# Altium schematic sheet 02_RNWF UART to Cloud Add on Board .SchDoc("02_RNWF UART to Cloud Add on Board ")
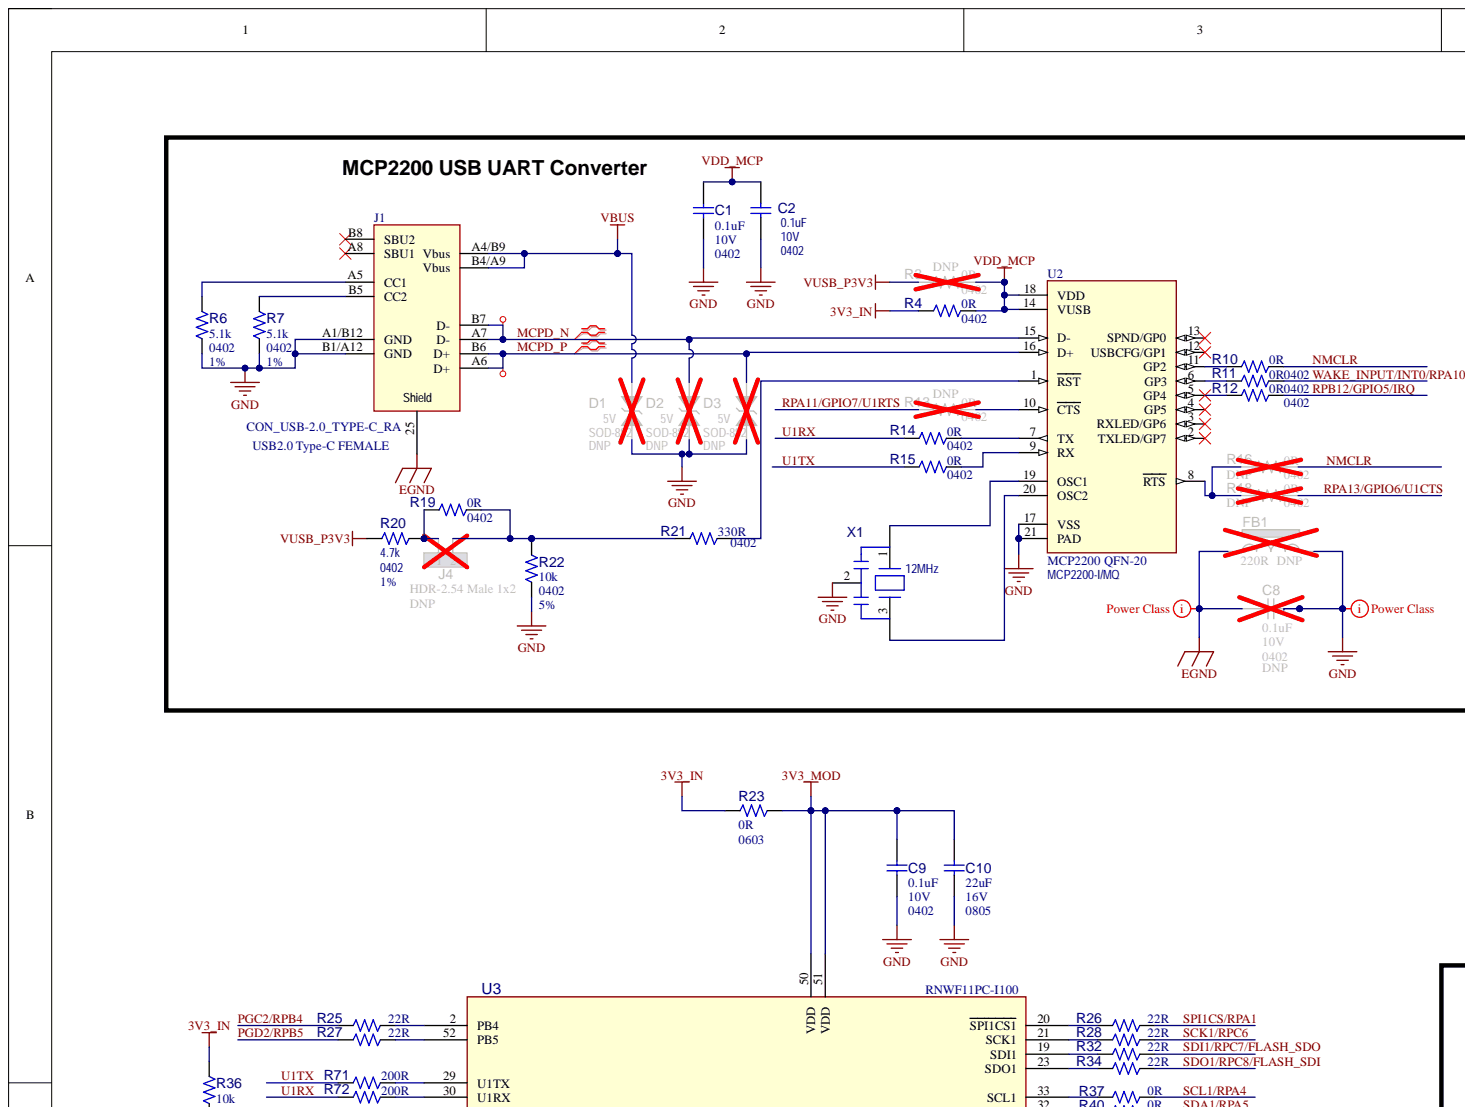
[diagram p0: 02_RNWF UART to Cloud Add on Board .SchDoc, top left]
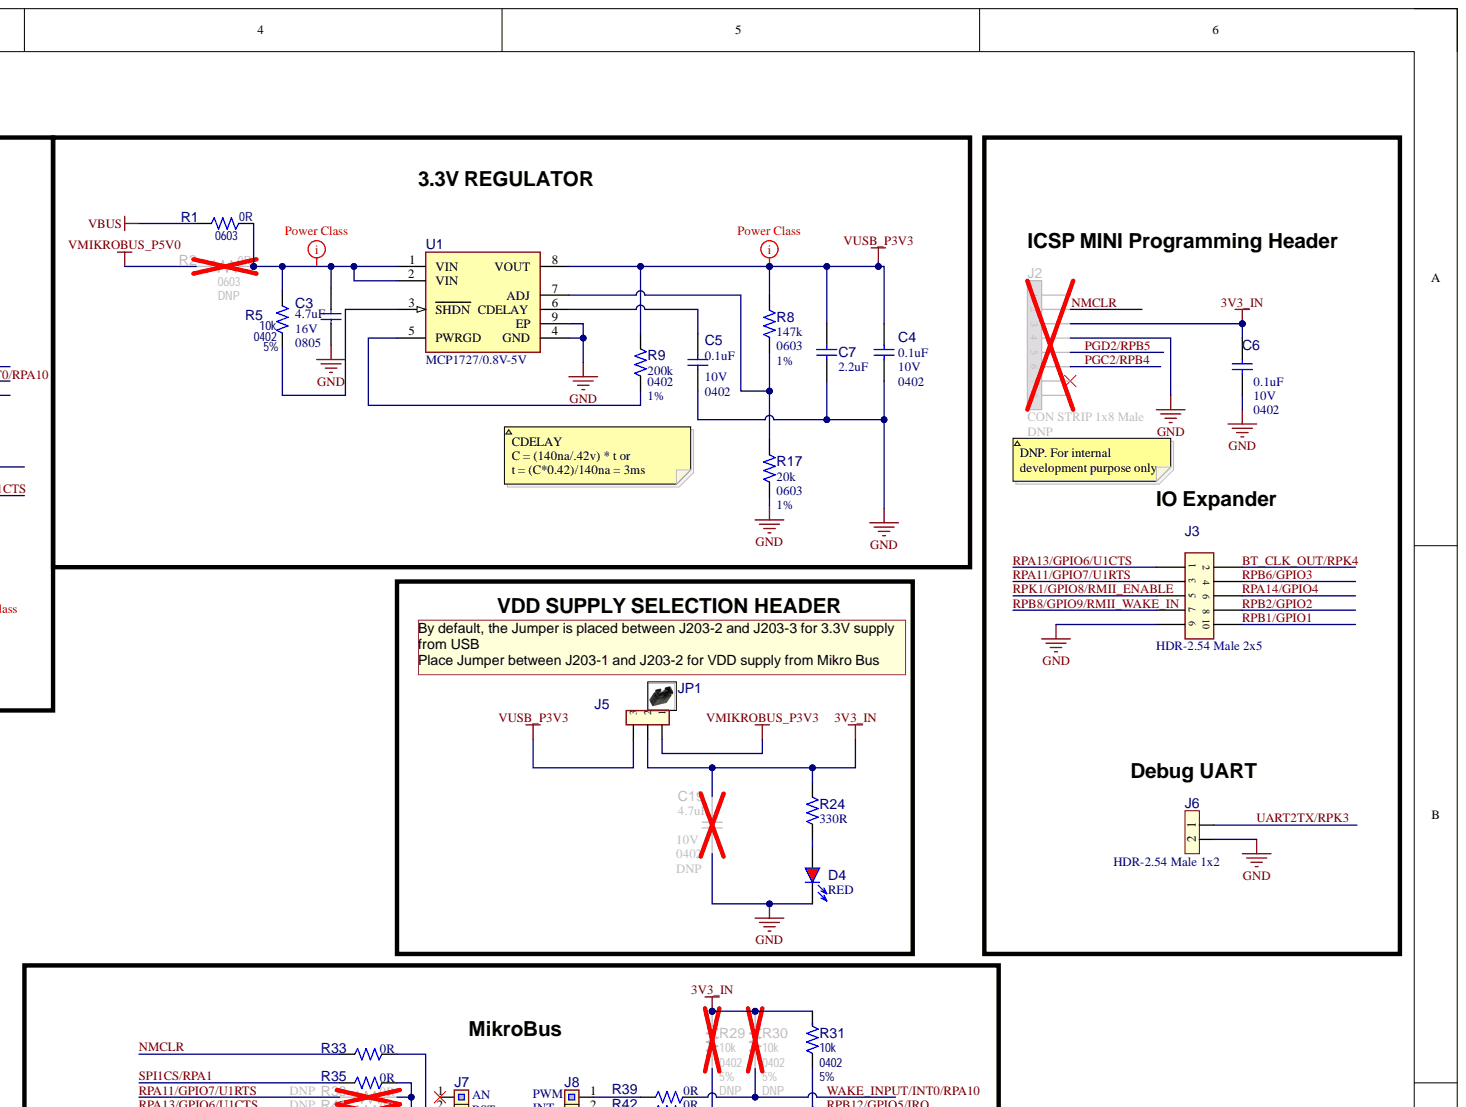
[diagram p1: 02_RNWF UART to Cloud Add on Board .SchDoc, top right]
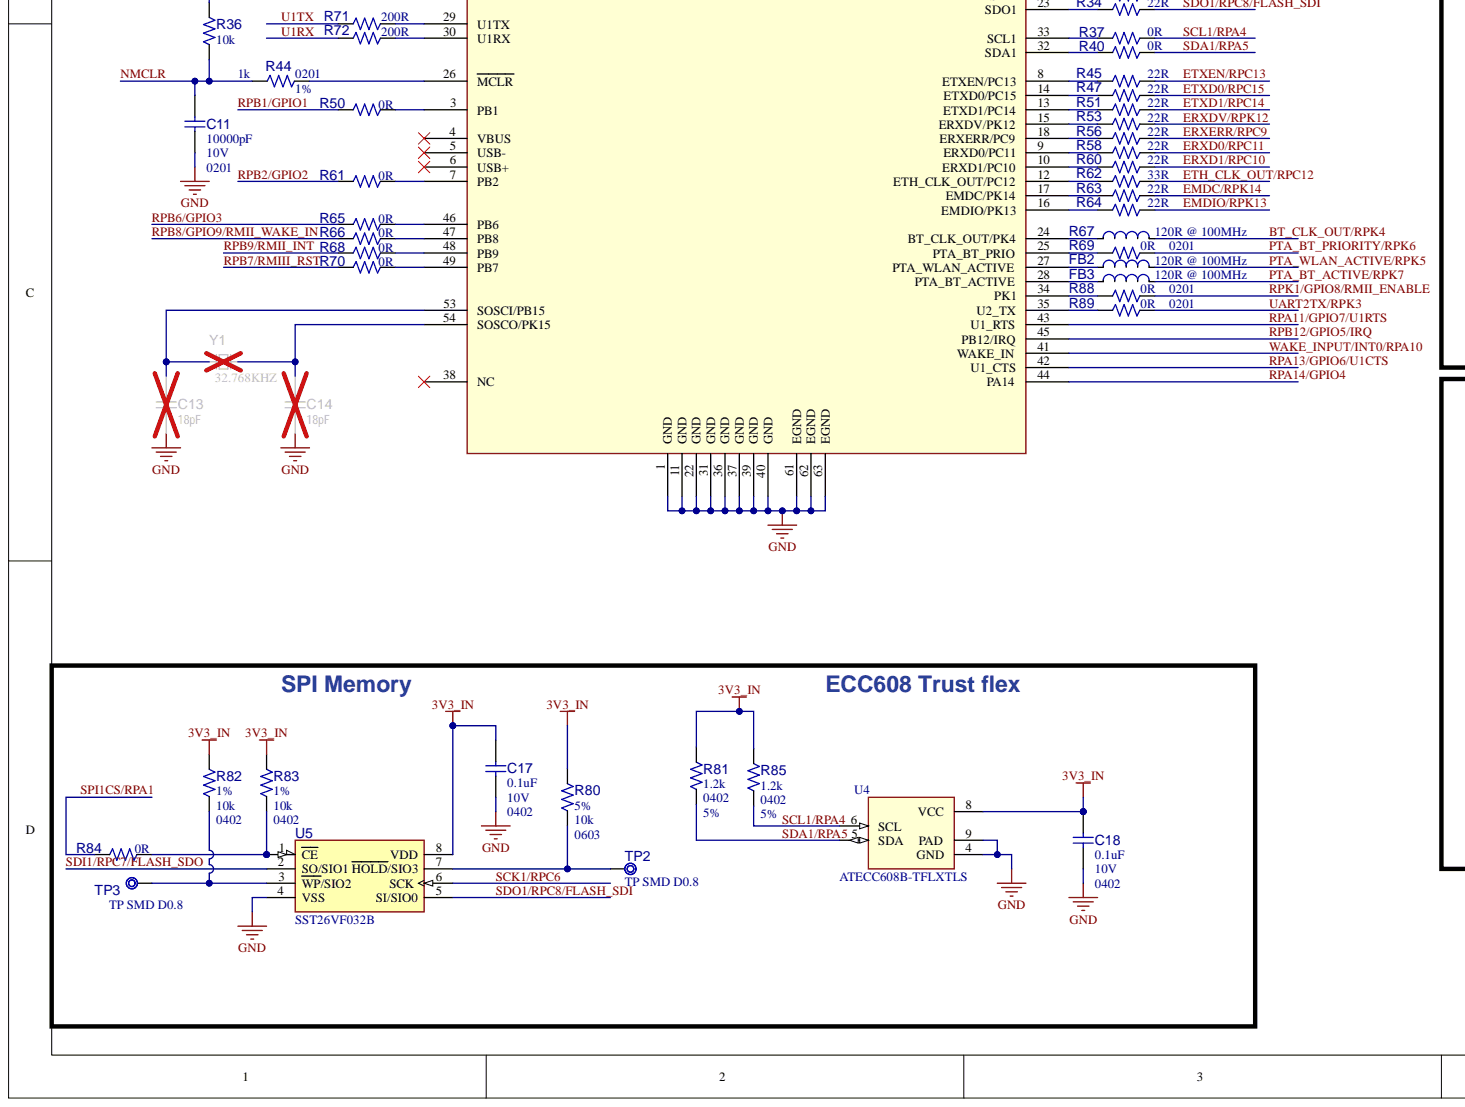
[diagram p2: 02_RNWF UART to Cloud Add on Board .SchDoc, bottom left]
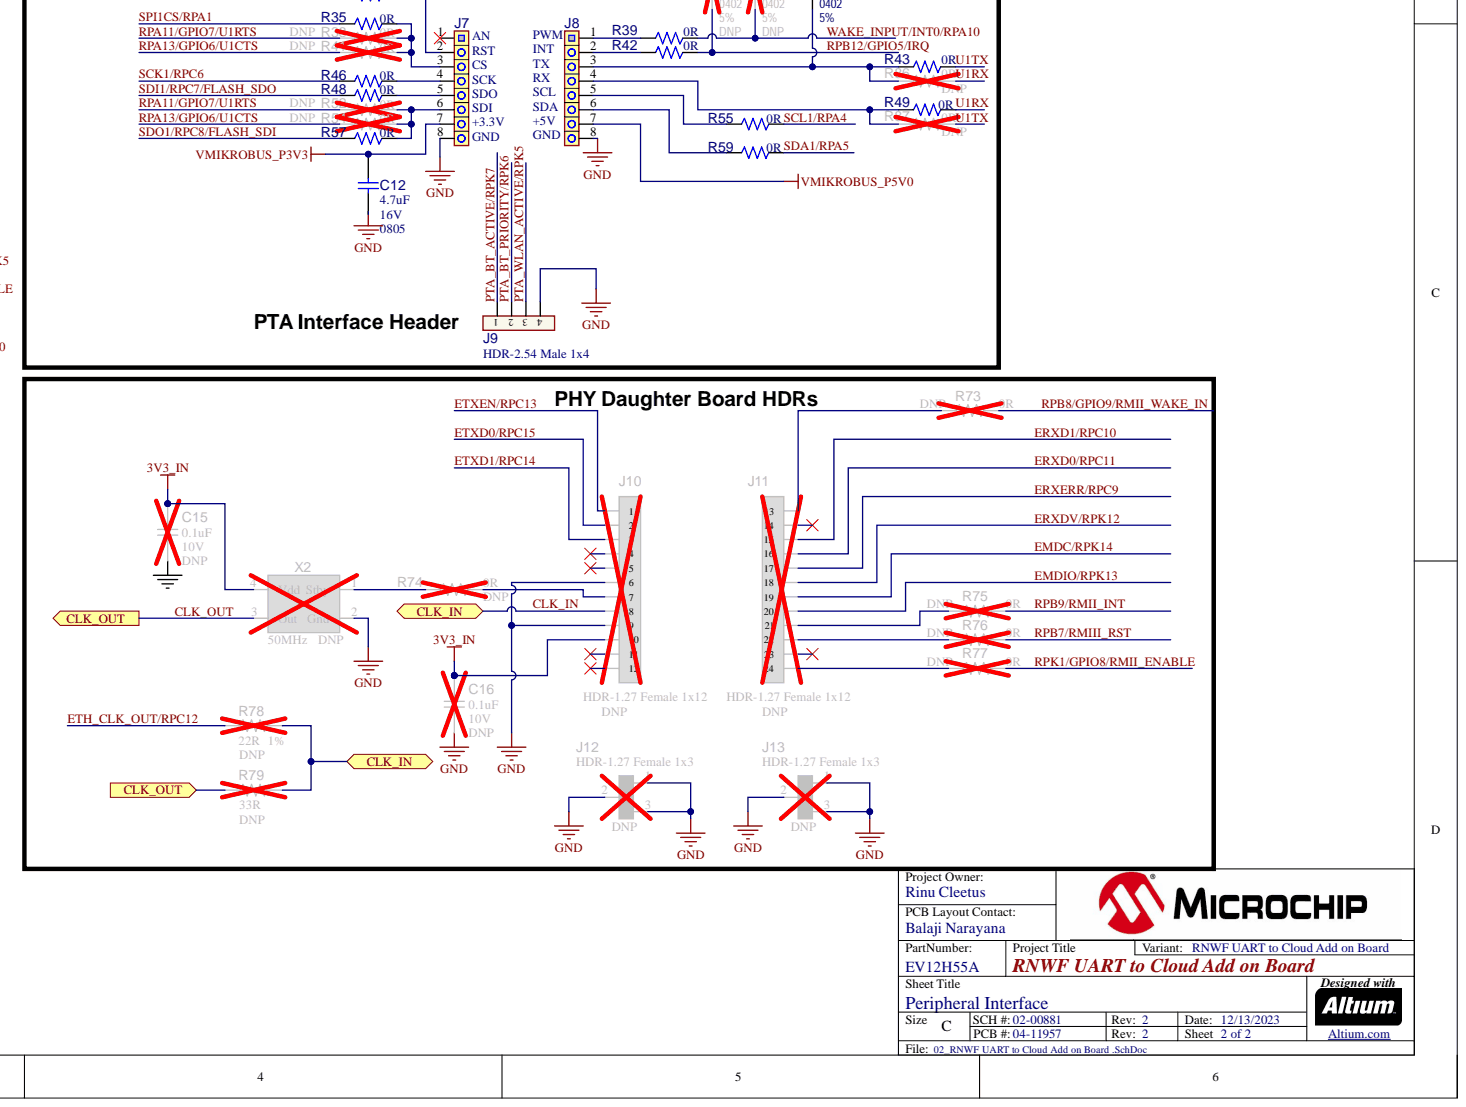
[diagram p3: 02_RNWF UART to Cloud Add on Board .SchDoc, bottom right]

## Parts
C1  value 0.1uF  Package: 0402  Voltage: 10V  [CAP_C_0402]  (hidden comment: Capacitor NP)
C2  value 0.1uF  Package: 0402  Voltage: 10V  [CAP_C_0402]  (hidden comment: Capacitor NP)
C3  value 4.7uF  Package: 0805  Voltage: 16V  [CAP_C_0805]  (hidden comment: Capacitor NP)
C4  value 0.1uF  Package: 0402  Voltage: 10V  [CAP_C_0402]  (hidden comment: Capacitor NP)
C5  value 0.1uF  Package: 0402  Voltage: 10V  [CAP_C_0402]  (hidden comment: Capacitor NP)
C6  value 0.1uF  Package: 0402  Voltage: 10V  [CAP_C_0402]  (hidden comment: Capacitor NP)
C7  value 2.2uF  [CAP_C_0603]  (hidden comment: Capacitor NP)
C8  value 0.1uF  Package: 0402  Populated: DNP  Voltage: 10V  [CAP_C_0402]  (hidden comment: Capacitor NP)
C9  value 0.1uF  Package: 0402  Voltage: 10V  [CAP_C_0402]  (hidden comment: Capacitor NP)
C10  value 22uF  Package: 0805  Voltage: 16V  [CAP_C_0805]  (hidden comment: Capacitor NP)
C11  value 10000pF  Package: 0201  Voltage: 10V  [CAP_C_0201]  (hidden comment: Capacitor NP)
C12  value 4.7uF  Package: 0805  Voltage: 16V  [CAP_C_0805]  (hidden comment: Capacitor NP)
C13  value 18pF  [CAP_C_0402]  (hidden comment: Capacitor NP)
C14  value 18pF  [CAP_C_0402]  (hidden comment: Capacitor NP)
C15  value 0.1uF  Populated: DNP  Voltage: 10V  [CAP_C_0402]  (hidden comment: Capacitor NP)
C16  value 0.1uF  Populated: DNP  Voltage: 10V  [CAP_C_0402]  (hidden comment: Capacitor NP)
C17  value 0.1uF  Package: 0402  Voltage: 10V  [CAP_C_0402]  (hidden comment: Capacitor NP)
C18  value 0.1uF  Package: 0402  Voltage: 10V  [CAP_C_0402]  (hidden comment: Capacitor NP)
C19  value 4.7uF  Package: 0402  Populated: DNP  Voltage: 10V  [CAP_C_0402]  (hidden comment: Capacitor NP)
D1  value 5V  Populated: DNP  Reverse Voltage: 5V  [SOD-882]  (hidden comment: Diode TVS Bidirectional)
D2  value 5V  Populated: DNP  Reverse Voltage: 5V  [SOD-882]  (hidden comment: Diode TVS Bidirectional)
D3  value 5V  Populated: DNP  Reverse Voltage: 5V  [SOD-882]  (hidden comment: Diode TVS Bidirectional)
D4  value RED  [LED_0603_RED]  (hidden comment: LED_red)
FB1  value 220R  Populated: DNP  [IND_C_0805]  (hidden comment: Ferrite Bead)
FB2  value 120R @ 100MHz  [RES_C_0402]  (hidden comment: Inductor)
FB3  value 120R @ 100MHz  [RES_C_0402]  (hidden comment: Inductor)
J1  CON_USB-2.0_TYPE-C_RA  value USB2.0 Type-C FEMALE  [CON_USB-2.0_TYPE-C_USB4105_SMD]
J2  value CON STRIP 1x8 Male  Populated: DNP  [HDR_M_1.27_1x8]  (hidden comment: Header 1x8)
J3  value HDR-2.54 Male 2x5  [HDR_M_2.54_2x5]  (hidden comment: Header 2x5)
J4  value HDR-2.54 Male 1x2  Populated: DNP  [HDR_M_2.54_1x2]  (hidden comment: Header 1x2)
J5  Header 1x3  [HDR_M_2.54_1x3]  (value not drawn)
J6  value HDR-2.54 Male 1x2  [HDR_M_2.54_1x2]  (hidden comment: Header 1x2)
J7  Header 1x8  [HDR_M_2.54_1x8]  (value not drawn)
J8  Header 1x8  [HDR_M_2.54_1x8]  (value not drawn)
J9  Header 1x4  value HDR-2.54 Male 1x4  [HDR_M_2.54_1x4]
J10  value HDR-1.27 Female 1x12  Populated: DNP  [HDR_F_1.27_1x12_U_TH_V_SAM_SLM-112-01-L-S]  (hidden comment: Header 1x12)
J11  value HDR-1.27 Female 1x12  Populated: DNP  [HDR_F_1.27_1x12_U_TH_V_SAM_SLM-112-01-L-S_1]  (hidden comment: Header 1x12)
J12  value HDR-1.27 Female 1x3  Populated: DNP  [HDR_F_1.27_1x3_U_TH_V_SAM_SLM-103-01-L-S]  (hidden comment: Header 1x3)
J13  value HDR-1.27 Female 1x3  Populated: DNP  [HDR_F_1.27_1x3_U_TH_V_SAM_SLM-103-01-L-S]  (hidden comment: Header 1x3)
JP1  Jumper Mechanical  [Jumper_W_Handle_Mechanical]  (value not drawn)  (mechanical)
R1  value 0R  Package: 0603  [RES_C_0603]  (hidden comment: Resistor)
R2  value 0R  Package: 0603  [RES_C_0603]  (hidden comment: Resistor)
R3  value 0R  Package: 0402  Populated: DNP  [RES_C_0402]  (hidden comment: Resistor)
R4  value 0R  Package: 0402  [RES_C_0402]  (hidden comment: Resistor)
R5  value 10k  Package: 0402  Tolerance: 5%  [RES_C_0402]  (hidden comment: Resistor)
R6  value 5.1k  Package: 0402  Tolerance: 1%  [RES_C_0402]  (hidden comment: Resistor)
R7  value 5.1k  Package: 0402  Tolerance: 1%  [RES_C_0402]  (hidden comment: Resistor)
R8  value 147k  Package: 0603  Tolerance: 1%  [RES_C_0603]  (hidden comment: Resistor)
R9  value 200k  Package: 0402  Tolerance: 1%  [RES_C_0402]  (hidden comment: Resistor)
R10  value 0R  Package: 0402  [RES_C_0402]  (hidden comment: Resistor)
R11  value 0R  Package: 0402  [RES_C_0402]  (hidden comment: Resistor)
R12  value 0R  Package: 0402  [RES_C_0402]  (hidden comment: Resistor)
R13  value 0R  Package: 0402  Populated: DNP  [RES_C_0402]  (hidden comment: Resistor)
R14  value 0R  Package: 0402  [RES_C_0402]  (hidden comment: Resistor)
R15  value 0R  Package: 0402  [RES_C_0402]  (hidden comment: Resistor)
R16  value 0R  Package: 0402  Populated: DNP  [RES_C_0402]  (hidden comment: Resistor)
R17  value 20k  Package: 0603  Tolerance: 1%  [RES_C_0603]  (hidden comment: Resistor)
R18  value 0R  Package: 0402  Populated: DNP  [RES_C_0402]  (hidden comment: Resistor)
R19  value 0R  Package: 0402  [RES_C_0402]  (hidden comment: Resistor)
R20  value 4.7k  Package: 0402  Tolerance: 1%  [RES_C_0402]  (hidden comment: Resistor)
R21  value 330R  Package: 0402  [RES_C_0402]  (hidden comment: Resistor)
R22  value 10k  Package: 0402  Tolerance: 5%  [RES_C_0402]  (hidden comment: Resistor)
R23  value 0R  Package: 0603  [RES_C_0603]  (hidden comment: Resistor)
R24  value 330R  [RES_C_0402]  (hidden comment: Resistor)
R25  value 22R  [RES_C_0402]  (hidden comment: Resistor)
R26  value 22R  [RES_C_0402]  (hidden comment: Resistor)
R27  value 22R  [RES_C_0402]  (hidden comment: Resistor)
R28  value 22R  [RES_C_0402]  (hidden comment: Resistor)
R29  value 10k  Package: 0402  Populated: DNP  Tolerance: 5%  [RES_C_0402]  (hidden comment: Resistor)
R30  value 10k  Package: 0402  Populated: DNP  Tolerance: 5%  [RES_C_0402]  (hidden comment: Resistor)
R31  value 10k  Package: 0402  Tolerance: 5%  [RES_C_0402]  (hidden comment: Resistor)
R32  value 22R  [RES_C_0402]  (hidden comment: Resistor)
R33  value 0R  [RES_C_0402]  (hidden comment: Resistor)
R34  value 22R  [RES_C_0402]  (hidden comment: Resistor)
R35  value 0R  [RES_C_0402]  (hidden comment: Resistor)
R36  value 10k  [RES_C_0402]  (hidden comment: Resistor)
R37  value 0R  [RES_C_0402]  (hidden comment: Resistor)
R38  value 0R  Populated: DNP  [RES_C_0402]  (hidden comment: Resistor)
R39  value 0R  [RES_C_0402]  (hidden comment: Resistor)
R40  value 0R  [RES_C_0402]  (hidden comment: Resistor)
R41  value 0R  Populated: DNP  [RES_C_0402]  (hidden comment: Resistor)
R42  value 0R  [RES_C_0402]  (hidden comment: Resistor)
R43  value 0R  [RES_C_0402]  (hidden comment: Resistor)
R44  value 1k  Package: 0201  Tolerance: 1%  [RES_C_0201]  (hidden comment: Resistor)
R45  value 22R  [RES_C_0402]  (hidden comment: Resistor)
R46  value 0R  [RES_C_0402]  (hidden comment: Resistor)
R47  value 22R  [RES_C_0402]  (hidden comment: Resistor)
R48  value 0R  [RES_C_0402]  (hidden comment: Resistor)
R49  value 0R  [RES_C_0402]  (hidden comment: Resistor)
R50  value 0R  [RES_C_0402]  (hidden comment: Resistor)
R51  value 22R  [RES_C_0402]  (hidden comment: Resistor)
R52  value 0R  Populated: DNP  [RES_C_0402]  (hidden comment: Resistor)
R53  value 22R  [RES_C_0402]  (hidden comment: Resistor)
R54  value 0R  Populated: DNP  [RES_C_0402]  (hidden comment: Resistor)
R55  value 0R  [RES_C_0402]  (hidden comment: Resistor)
R56  value 22R  [RES_C_0402]  (hidden comment: Resistor)
R57  value 0R  [RES_C_0402]  (hidden comment: Resistor)
R58  value 22R  [RES_C_0402]  (hidden comment: Resistor)
R59  value 0R  [RES_C_0402]  (hidden comment: Resistor)
R60  value 22R  [RES_C_0402]  (hidden comment: Resistor)
R61  value 0R  [RES_C_0402]  (hidden comment: Resistor)
R62  value 33R  [RES_C_0402]  (hidden comment: Resistor)
R63  value 22R  [RES_C_0402]  (hidden comment: Resistor)
R64  value 22R  [RES_C_0402]  (hidden comment: Resistor)
R65  value 0R  [RES_C_0402]  (hidden comment: Resistor)
R66  value 0R  [RES_C_0402]  (hidden comment: Resistor)
R67  value 120R @ 100MHz  [RES_C_0402]  (hidden comment: Inductor)
R68  value 0R  [RES_C_0402]  (hidden comment: Resistor)
R69  value 0R  Package: 0201  [RES_C_0201]  (hidden comment: Resistor)
R70  value 0R  [RES_C_0402]  (hidden comment: Resistor)
R71  value 200R  [RES_C_0402]  (hidden comment: Resistor)
R72  value 200R  [RES_C_0402]  (hidden comment: Resistor)
R73  value 0R  Populated: DNP  [RES_C_0402]
R74  value 0R  Populated: DNP  [RES_C_0402]
R75  value 0R  Populated: DNP  [RES_C_0402]
R76  value 0R  Populated: DNP  [RES_C_0402]
R77  value 0R  Populated: DNP  [RES_C_0402]
R78  value 22R  Tolerance: 1%  [RES_C_0402]  (hidden comment: Resistor)
R79  value 33R  Populated: DNP  [RES_C_0402]  (hidden comment: Resistor)
R80  value 10k  Package: 0603  Tolerance: 5%  [RES_C_0603]  (hidden comment: RES0191)
R81  value 1.2k  Package: 0402  Tolerance: 5%  [RES_C_0402]  (hidden comment: Resistor)
R82  value 10k  Package: 0402  Tolerance: 1%  [RES_C_0402]  (hidden comment: RSMT1019)
R83  value 10k  Package: 0402  Tolerance: 1%  [RES_C_0402]  (hidden comment: RSMT1019)
R84  value 0R  [RES_C_0402]  (hidden comment: Resistor)
R85  value 1.2k  Package: 0402  Tolerance: 5%  [RES_C_0402]  (hidden comment: Resistor)
R86  value 0R  Populated: DNP  [RES_C_0402]  (hidden comment: Resistor)
R87  value 0R  Populated: DNP  [RES_C_0402]  (hidden comment: Resistor)
R88  value 0R  Package: 0201  [RES_C_0201]  (hidden comment: Resistor)
R89  value 0R  Package: 0201  [RES_C_0201]  (hidden comment: Resistor)
TP2  value TP SMD D0.8  [CON_TP_0.8dia_SMD]  (hidden comment: Test Point No BOM)  (no BOM)
TP3  value TP SMD D0.8  [CON_TP_0.8dia_SMD]  (hidden comment: Test Point No BOM)  (no BOM)
U1  value MCP1727/0.8V-5V  [DFN-8_3x3]  (hidden comment: MCP172X ADJ DFN-8)
U2  MCP2200 QFN-20  value MCP2200-I/MQ  Manufacturer Part Number: MCP2200-I/MQ  [QFN-20_5X5]
U3  value RNWF11PC-I100  Manufacturer Part Number 1: RNWF11PC-I100  [MOD_WFI32E01x]  (hidden comment: MODULE RNWF11PC-I)
U4  value ATECC608B-TFLXTLS  [UDFN-8_2X3_EP1.5X1.3]  (hidden comment: ATECC608B-MAHDA-B UDFN-8)
U5  value SST26VF032B  [SOIJ-8]  (hidden comment: SST26VFXXX SOIC-8_WSON-8_SOIJ-8)
X1  value 12MHz  [RESONATOR_CSTCE-G_L3.2W1.3H0.8]  (hidden comment: Resonator 3 Pins)
X2  value 50MHz  Populated: DNP  [CRYSTAL_ASEMB]  (hidden comment: XTAL_OSC-ASEMB)
Y1  value 32.768KHZ  [CRYSTAL_FC-12M_L2.05W1.2H0.6]  (hidden comment: Crystal 2 Pins)

## Ports
CLK_IN, CLK_OUT

## Nets
3V3_IN: C6.1 C15.2 C16.2 C17.1 C18.1 C19.2 J2.3 J5.2 J10.10 R4.1 R23.1 R24.1 R29.2 R30.2 R31.2 R36.2 R80.2 R81.2 R82.2 R83.2 R85.2 U4.8(VCC) U5.8(VDD) X2.4(Vdd)
3V3_MOD: C9.2 C10.2 R23.2 U3.50(VDD) U3.51(VDD)
EGND: C8.2 FB1.1 J1.25
GND: C1.2 C2.1 C3.1 C4.1 C5.1 C6.2 C7.1 C8.1 C9.1 C10.1 C11.1 C12.1 C13.1 C14.1 C15.1 C16.1 C17.2 C18.2 C19.1 D1.1 D2.1 D3.1 D4.2 FB1.2 J1.A1/B12(GND) J1.B1/A12(GND) J2.4 J3.9 J6.2 J7.8 J8.8 J9.4 J10.6 J10.9 J12.1 J12.2 J12.3 J13.1 J13.2 J13.3 R6.1 R7.1 R17.1 R22.1 U1.4(GND) U1.9(EP) U2.17(VSS) U2.21(PAD) U3.1(GND) U3.11(GND) U3.22(GND) U3.31(GND) U3.36(GND) U3.37(GND) U3.39(GND) U3.40(GND) U3.61(EGND) U3.62(EGND) U3.63(EGND) U4.4(GND) U4.9(PAD) U5.4(VSS) X1.2 X2.2(Gnd)
VBUS: D1.2 J1.A4/B9(Vbus) J1.B4/A9(Vbus) R1.1
VDD_MCP: C1.1 C2.2 R3.2 R4.2 U2.14(VUSB) U2.18(VDD)
VMIKROBUS_P3V3: C12.2 J5.1 J7.7
VMIKROBUS_P5V0: J8.7 R2.1
VUSB_P3V3: C4.2 C7.2 J5.3 R3.1 R8.2 R9.2 R20.2 U1.8(VOUT)
BT_CLK_OUT/RPK4: J3.2 R67.2
CLK_IN: J10.8 R78.2 R79.2 ; port CLK_IN
CLK_OUT: R79.1 X2.3(Out) ; port CLK_OUT
EMDC/RPK14: J11.19 R63.1
EMDIO/RPK13: J11.20 R64.1
ERXD0/RPC11: J11.16 R58.1
ERXD1/RPC10: J11.15 R60.1
ERXDV/RPK12: J11.18 R53.1
ERXERR/RPC9: J11.17 R56.1
ETH_CLK_OUT/RPC12: R62.1 R78.1
ETXD0/RPC15: J10.2 R47.1
ETXD1/RPC14: J10.3 R51.1
ETXEN/RPC13: J10.1 R45.1
MCPD_N: D2.2 J1.A7(D-) J1.B7(D-) U2.15(D-)
MCPD_P: D3.2 J1.A6(D+) J1.B6(D+) U2.16(D+)
NMCLR: C11.2 J2.2 R10.2 R16.2 R33.1 R36.1 R44.1
PGC2/RPB4: J2.6 R25.2
PGD2/RPB5: J2.5 R27.2
PTA_BT_ACTIVE/RPK7: FB3.2 J9.1
PTA_BT_PRIORITY/RPK6: J9.2 R69.2
PTA_WLAN_ACTIVE/RPK5: FB2.2 J9.3
RPA11/GPIO7/U1RTS: J3.3 R13.1 R38.1 R52.1 U3.43(U1_RTS)
RPA13/GPIO6/U1CTS: J3.1 R18.2 R41.1 R54.1 U3.42(U1_CTS)
RPA14/GPIO4: J3.6 U3.44(PA14)
RPB1/GPIO1: J3.10 R50.1
RPB2/GPIO2: J3.8 R61.1
RPB6/GPIO3: J3.4 R65.1
RPB7/RMIII_RST: R70.1 R76.2
RPB8/GPIO9/RMII_WAKE_IN: J3.7 R66.1 R73.2
RPB9/RMII_INT: R68.1 R75.2
RPB12/GPIO5/IRQ: R12.2 R29.1 R42.2 U3.45(PB12/IRQ)
RPK1/GPIO8/RMII_ENABLE: J3.5 R77.2 R88.2
SCK1/RPC6: R28.1 R46.1 U5.6(SCK)
SCL1/RPA4: R37.2 R55.2 R85.1 U4.6(SCL)
SDA1/RPA5: R40.2 R59.2 R81.1 U4.5(SDA)
SDI1/RPC7/FLASH_SDO: R32.1 R48.1 U5.2(SO/SIO1)
SDO1/RPC8/FLASH_SDI: R34.1 R57.1 U5.5(SI/SIO0)
SPI1CS/RPA1: R26.1 R35.1 R84.1
U1RX: R14.1 R49.2 R72.2 R86.2
U1TX: R15.1 R43.2 R71.2 R87.2
UART2TX/RPK3: J6.1 R89.2
WAKE_INPUT/INT0/RPA10: R11.2 R30.1 R39.2 U3.41(WAKE_IN)
NetC3_2: C3.2 R1.2 R2.2 R5.2 U1.1(VIN) U1.2(VIN)
NetC5_2: C5.2 U1.6(CDELAY)
NetC13_2: C13.2 U3.53(SOSCI/PB15) Y1.2
NetC14_2: C14.2 U3.54(SOSCO/PK15) Y1.1
NetD4_1: D4.1 R24.2
NetFB2_1: FB2.1 U3.27(PTA_WLAN_ACTIVE)
NetFB3_1: FB3.1 U3.28(PTA_BT_ACTIVE)
NetJ1_A5: J1.A5(CC1) R6.2
NetJ1_B5: J1.B5(CC2) R7.2
NetJ4_1: J4.1 R19.1 R20.1
NetJ4_2: J4.2 R19.2 R21.1 R22.2
NetJ7_2: J7.2 R33.2
NetJ7_3: J7.3 R35.2 R38.2 R41.2
NetJ7_4: J7.4 R46.2
NetJ7_5: J7.5 R48.2
NetJ7_6: J7.6 R52.2 R54.2 R57.2
NetJ8_1: J8.1 R39.1
NetJ8_2: J8.2 R42.1
NetJ8_3: J8.3 R31.1 R43.1 R86.1
NetJ8_4: J8.4 R49.1 R87.1
NetJ8_5: J8.5 R55.1
NetJ8_6: J8.6 R59.1
NetJ10_7: J10.7 R74.2
NetJ11_13: J11.13 R73.1
NetJ11_21: J11.21 R75.1
NetJ11_22: J11.22 R76.1
NetJ11_24: J11.24 R77.1
NetR5_1: R5.1 U1.3(SHDN)
NetR8_1: R8.1 R17.2 U1.7
NetR9_1: R9.1 U1.5(PWRGD)
NetR10_1: R10.1 U2.11(GP2)
NetR11_1: R11.1 U2.6(GP3)
NetR12_1: R12.1 U2.5(GP4)
NetR13_2: R13.2 U2.10(CTS)
NetR14_2: R14.2 U2.7(TX)
NetR15_2: R15.2 U2.9(RX)
NetR16_1: R16.1 R18.1 U2.8(RTS)
NetR21_2: R21.2 U2.1(RST)
NetR25_1: R25.1 U3.2(PB4)
NetR26_2: R26.2 U3.20(SPI1CS1)
NetR27_1: R27.1 U3.52(PB5)
NetR28_2: R28.2 U3.21(SCK1)
NetR32_2: R32.2 U3.19(SDI1)
NetR34_2: R34.2 U3.23(SDO1)
NetR37_1: R37.1 U3.33(SCL1)
NetR40_1: R40.1 U3.32(SDA1)
NetR44_2: R44.2 U3.26(MCLR)
NetR45_2: R45.2 U3.8(ETXEN/PC13)
NetR47_2: R47.2 U3.14(ETXD0/PC15)
NetR50_2: R50.2 U3.3(PB1)
NetR51_2: R51.2 U3.13(ETXD1/PC14)
NetR53_2: R53.2 U3.15(ERXDV/PK12)
NetR56_2: R56.2 U3.18(ERXERR/PC9)
NetR58_2: R58.2 U3.9(ERXD0/PC11)
NetR60_2: R60.2 U3.10(ERXD1/PC10)
NetR61_2: R61.2 U3.7(PB2)
NetR62_2: R62.2 U3.12(ETH_CLK_OUT/PC12)
NetR63_2: R63.2 U3.17(EMDC/PK14)
NetR64_2: R64.2 U3.16(EMDIO/PK13)
NetR65_2: R65.2 U3.46(PB6)
NetR66_2: R66.2 U3.47(PB8)
NetR67_1: R67.1 U3.24(BT_CLK_OUT/PK4)
NetR68_2: R68.2 U3.48(PB9)
NetR69_1: R69.1 U3.25(PTA_BT_PRIO)
NetR70_2: R70.2 U3.49(PB7)
NetR71_1: R71.1 U3.29(U1TX)
NetR72_1: R72.1 U3.30(U1RX)
NetR74_1: R74.1 X2.1(Stby)
NetR80_1: R80.1 TP2.1 U5.7(HOLD/SIO3)
NetR82_1: R82.1 TP3.1 U5.3(WP/SIO2)
NetR83_1: R83.1 R84.2 U5.1(CE)
NetR88_1: R88.1 U3.34(PK1)
NetR89_1: R89.1 U3.35(U2_TX)
NetU2_19: U2.19(OSC1) X1.1
NetU2_20: U2.20(OSC2) X1.3

Not connected: J1 A8(SBU1) B8(SBU2); J2 1 7 8; J7 1; J10 4 5 11 12; J11 14 23; U2 2(TXLED/GP7) 3(RXLED/GP6) 4(GP5) 12(USBCFG/GP1) 13(SPND/GP0); U3 4(VBUS) 5(USB-) 6(USB+) 38(NC)
